# Altium schematic sheet 4_SD_Card_NFC.SchDoc
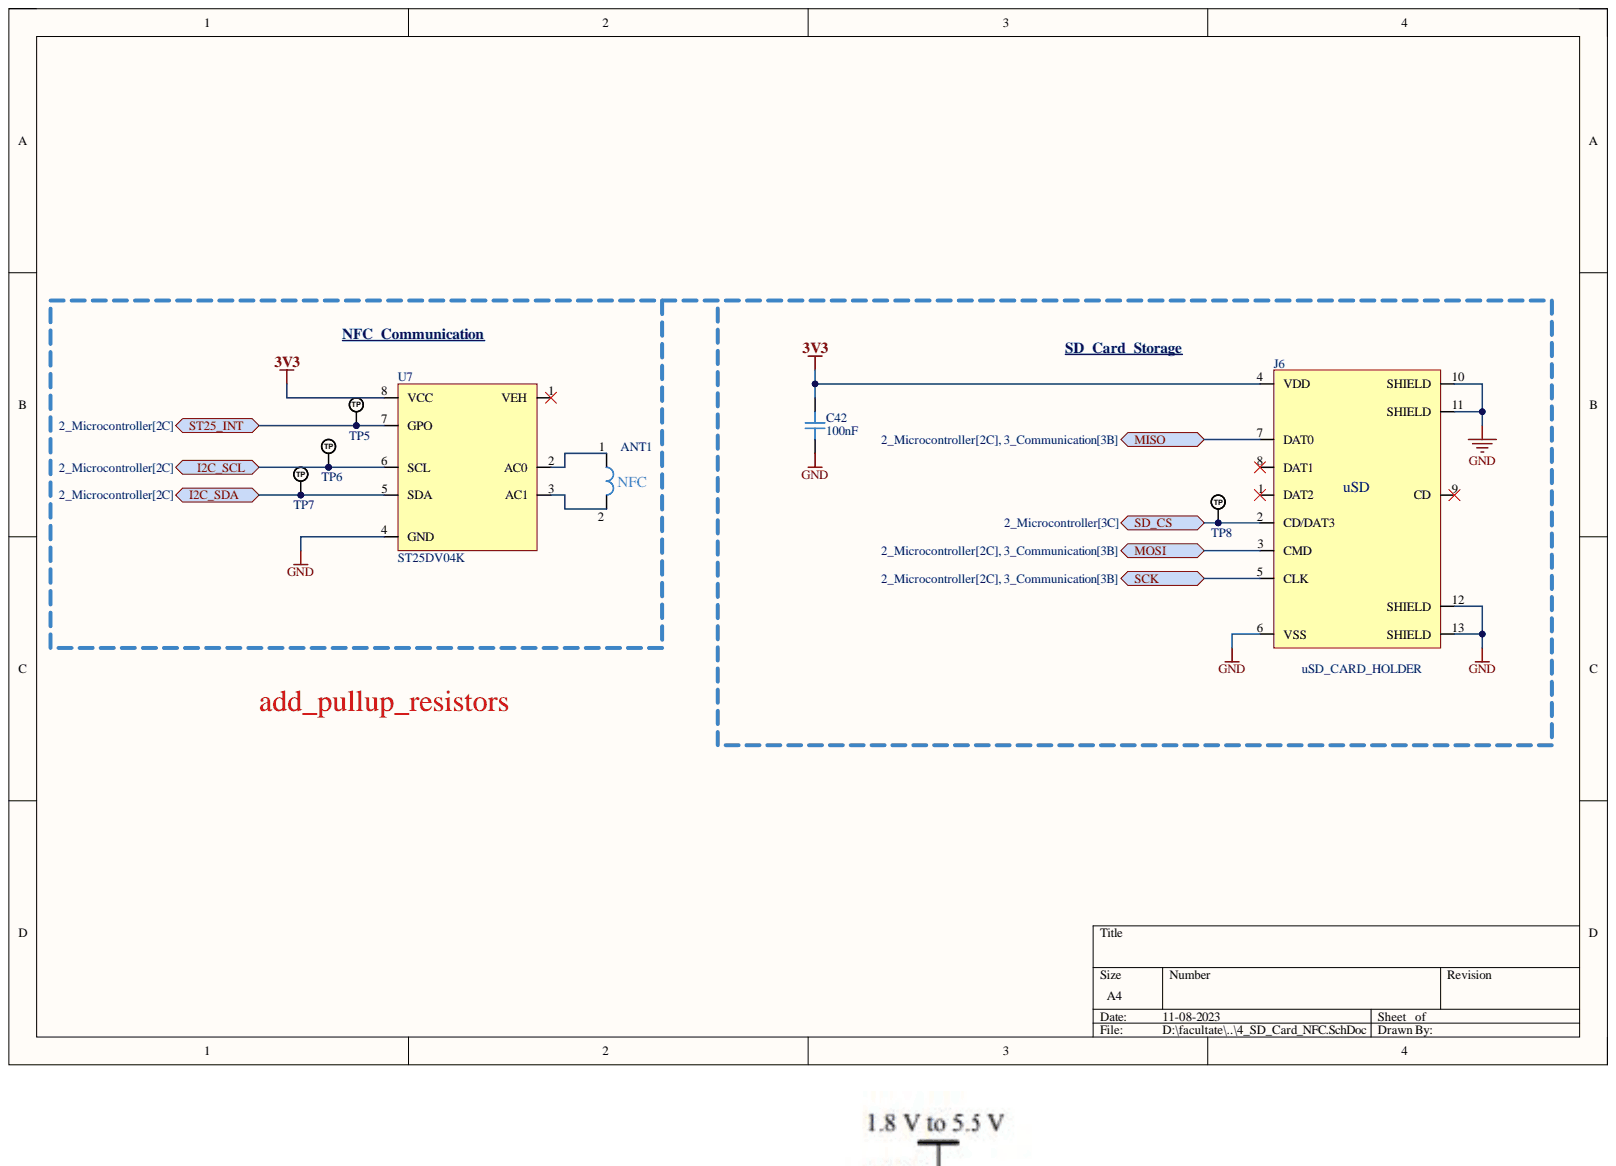
[diagram p0: 4_SD_Card_NFC.SchDoc]

## Parts
ANT1  NFC_ANTENNA  (value not drawn)
C42  100nF  [CAP_0603]
J6  uSD_CARD_HOLDER
TP5  TP_NOBOM  [PAD_RND_1MM]  (value not drawn)  (no BOM)
TP6  TP_NOBOM  [PAD_RND_1MM]  (value not drawn)  (no BOM)
TP7  TP_NOBOM  [PAD_RND_1MM]  (value not drawn)  (no BOM)
TP8  TP_NOBOM  [PAD_RND_1MM]  (value not drawn)  (no BOM)
U7  ST25DV04K

## Ports
I2C_SCL, I2C_SDA, MISO, MOSI, SCK, SD_CS, ST25_INT

## Nets
3V3: C42.2 J6.4(VDD) U7.8(VCC)
GND: C42.1 J6.6(VSS) J6.10(SHIELD) J6.11(SHIELD) J6.12(SHIELD) J6.13(SHIELD) U7.4(GND)
I2C_SCL: TP6.1 U7.6(SCL) ; port I2C_SCL
I2C_SDA: TP7.1 U7.5(SDA) ; port I2C_SDA
MISO: J6.7(DAT0) ; port MISO
MOSI: J6.3(CMD) ; port MOSI
SCK: J6.5(CLK) ; port SCK
SD_CS: J6.2(CD/DAT3) TP8.1 ; port SD_CS
ST25_INT: TP5.1 U7.7(GPO) ; port ST25_INT
NetANT1_1: ANT1.1 U7.2(AC0)
NetANT1_2: ANT1.2 U7.3(AC1)

Not connected: J6 1(DAT2) 8(DAT1) 9(CD); U7 1(VEH)

## Library data of the parts
C42 = CAP_0603: Capacitor SMD 0603; Component Kind: Standard; Library Name: Schlib_H2.SchLib
J6 = uSD_CARD: Component Kind: Standard; Library Name: Schlib_H2.SchLib
TP5-TP8 = TEST_POINT_RND_1MM: Test Point Round 1mm (NO BOM); Library Name: Schlib_H2.SchLib
U7 = ST25DV04K: ST25DO4K NFC module with I2C; Component Kind: Standard; Library Name: Schlib1.SchLib
ANT1 = NFC_ANTENNA: Component Kind: Standard; Library Name: Schlib1.SchLib
